# Altium schematic sheet CW551_MainBoard_USB.SchDoc
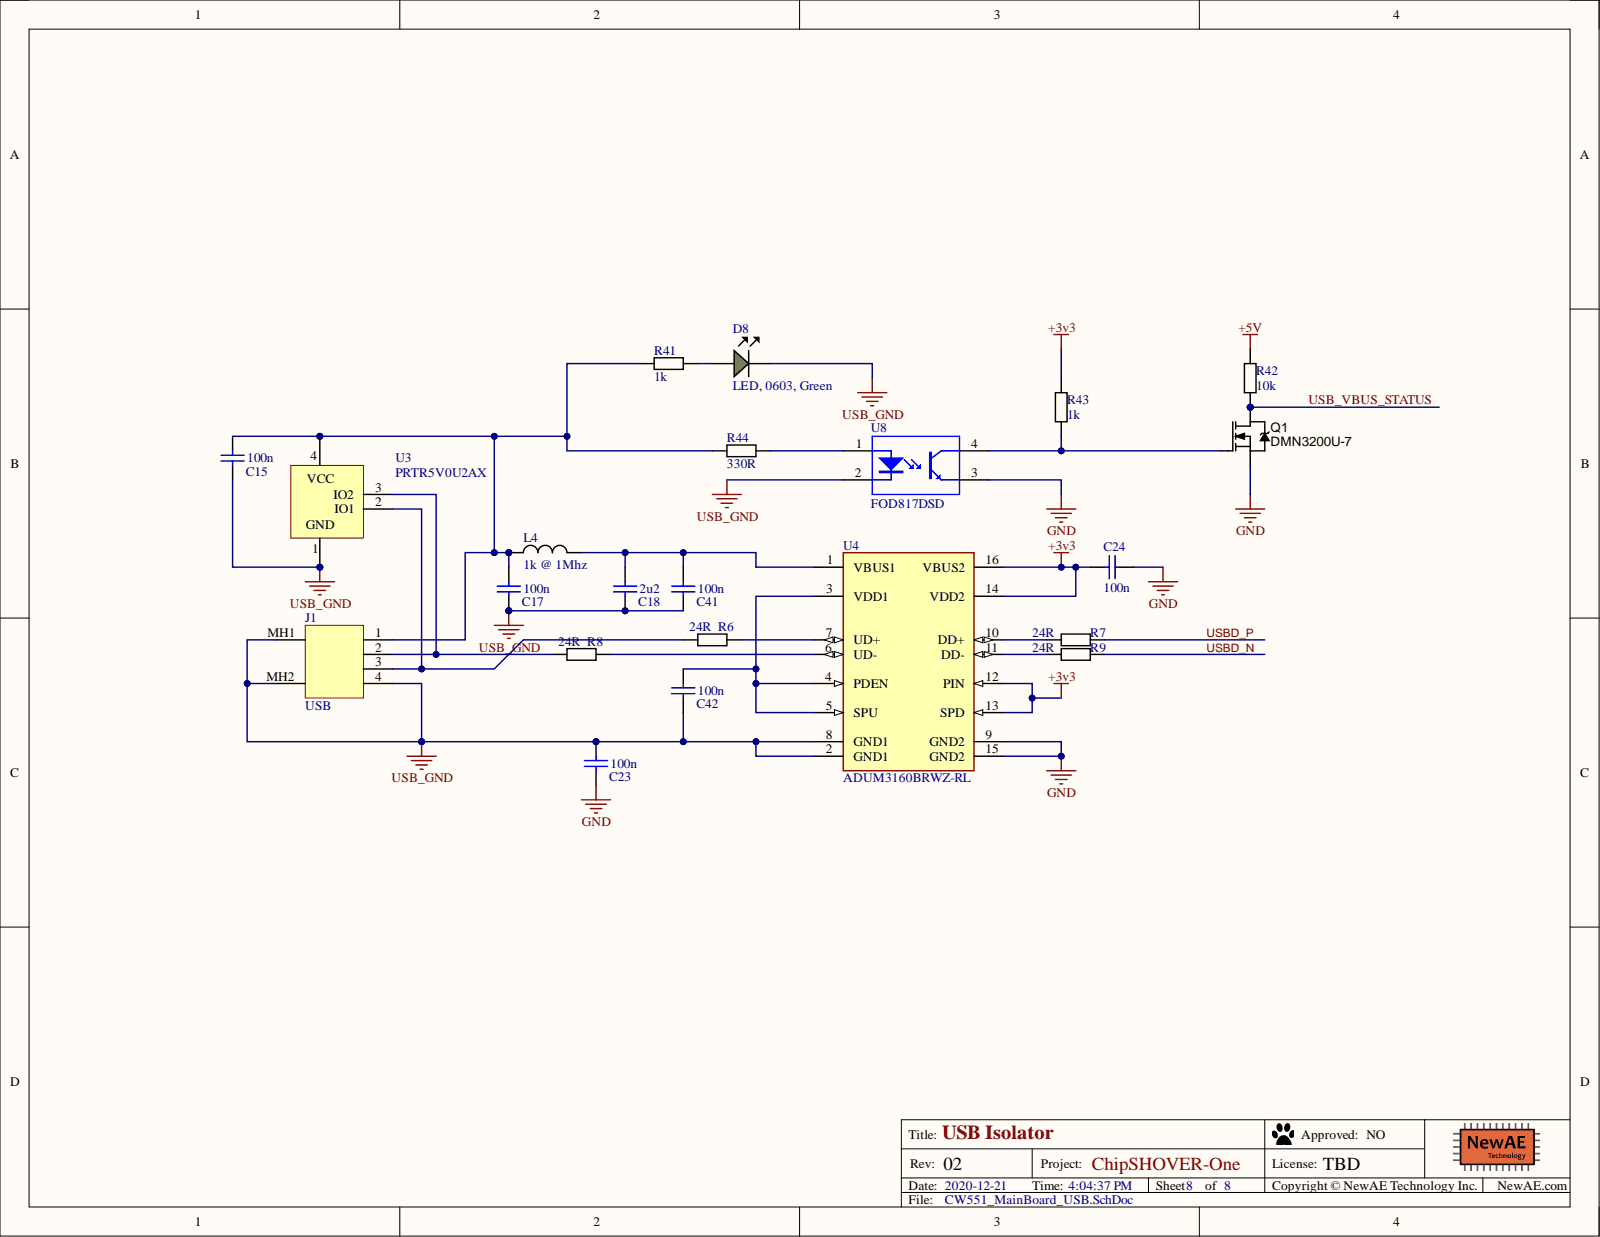
[diagram p0: CW551_MainBoard_USB.SchDoc]

## Parts
C15  100n  [0603]
C17  100n  [0603]
C18  2u2  [0603]
C23  100n  [0603]
C24  100n  [0603]
C41  100n  [0603]
C42  100n  [0603]
D8  LED, 0603, Green  [LED-0603-GREEN]
J1  USB  [USB_61729-0010BLF-6_V]
L4  1k @ 1Mhz  [0603]
Q1  DMN3200U-7  [SOT23]
R6  24R  [0603]
R7  24R  [0603]
R8  24R  [0603]
R9  24R  [0603]
R41  1k  [0603]
R42  10k  [0603]
R43  1k  [0603]
R44  330R  [0603]
U3  PRTR5V0U2AX  [SOT143B]
U4  ADUM3160BRWZ-RL  [FP-RW-16-IPC_C]
U8  FOD817DSD  [FAIR-MDIP-4_V]

## Nets
+3v3: C24.1 R43.1 U4.12(PIN) U4.13(SPD) U4.14(VDD2) U4.16(VBUS2)
+5V: R42.1
GND: C23.2 C24.2 Q1.2 U4.9(GND2) U4.15(GND2) U8.3
USB_GND: C15.2 C17.2 C18.2 C23.1 C41.2 C42.2 D8.K J1.4 J1.MH1 J1.MH2 U3.1 U4.2(GND1) U4.8(GND1) U8.2
USB_VBUS_STATUS: Q1.3 R42.2
USBD_N: R9.2
USBD_P: R7.2
NetC15_1: C15.1 C17.1 J1.1 L4.1 R41.1 R44.1 U3.4
NetC18_1: C18.1 C41.1 L4.2 U4.1(VBUS1)
NetC42_1: C42.1 U4.3(VDD1) U4.4(PDEN) U4.5(SPU)
NetD8_A: D8.A R41.2
NetJ1_2: J1.2 R8.1 U3.3(IO2)
NetJ1_3: J1.3 R6.1 U3.2(IO1)
NetQ1_1: Q1.1 R43.2 U8.4
NetR6_2: R6.2 U4.7(UD+)
NetR7_1: R7.1 U4.10(DD+)
NetR8_2: R8.2 U4.6(UD-)
NetR9_1: R9.1 U4.11(DD-)
NetR44_2: R44.2 U8.1

## Library data of the parts
C15 C17 C23 C24 C41 C42 = CCHIP-100n-0603: 100n, 0603; Manufacture 1: Kemet; Manufacture Part Number 1: C0603C104K5RACTU; Case-Metric: 1608; Component Group: Capacitor; Database Table Name: main; Rated Voltage: 50VDC; Case-EIA: 0603; Component Kind: Standard; Component Type: Standard; LCSC Equivalent: C1591; Library Name: NewAE_AltiumLib.DBLib; Mounting Technology: SMT; Part Number: CCHIP-100n-0603; Pin Count: 2; Supplier 1: Digi-Key; Supplier Part Number 1: 399-5089-2-ND; Value: 100n
C18 = CCHIP-2u2-0603: 2u2, 0603; Manufacture 1: Samsung; Manufacture Part Number 1: CL10B225KP8NNNC; Case-Metric: 1608; Component Group: Capacitor; Database Table Name: main; Rated Voltage: 10VDC; Case-EIA: 0603; Component Kind: Standard; Component Type: Standard; LCSC Equivalent: C57895; Library Name: NewAE_AltiumLib.DBLib; Mounting Technology: SMT; Part Number: CCHIP-2u2-0603; Pin Count: 2; Supplier 1: Digi-Key; Supplier Part Number 1: 1276-1134-2-ND; Value: 2u2
D8 = LEDCHIP_0603_GREEN: Chip LED, Green; Manufacture 1: Kingbright; Manufacture Part Number 1: APT1608CGCK; Component Group: Optical; Database Table Name: main; PP Material Stack: 16; PP Rotation: -90; Component Kind: Standard; Component Type: Standard; LCSC Equivalent: C192666; Library Name: NewAE_AltiumLib.DBLib; Mounting Technology: SMT; Part Number: LEDCHIP_0603_GREEN; Pin Count: 2; Supplier 1: Digi-Key; Supplier Part Number 1: 754-1116-1-ND
J1 = CMP-0397-00002-2: Single USB 2.0, B Type, Right Angle Jack, Through Hole, RoHS, Tube; Manufacturer: FCI Electronics; PartNumber: 61729-0010BLF; 0_TEMP: FCI-61729-0010BLF-6_V; Connector Type: USB-B; Gender: Receptacle; Number of Contacts: 4; Specifications: USB 2.0; Component Kind: Standard; ComponentLink1Description: Manufacturer URL; ComponentLink1URL: http://portal.fciconnect.com/; ComponentLink2Description: Datasheet; ComponentLink2URL: http://portal.fciconnect.com/Comergent//fci/drawing/61729.pdf; ComponentLink3Description: Package Specification; ComponentLink3URL: http://portal.fciconnect.com/Comergent//fci/drawing/61729.pdf; DatasheetVersion: Rev. V; Functionality: USB; Library Name: CW550_MAIN.SCHLIB; Mounting Technology: Through Hole; PackageDescription: 6-Pin, Through Hole, Body 12.2 x 16 mm; PackageReference: 61729-0010BLF; Packing: Tube; RoHS: TRUE; Supplier 1: Digi-Key; Supplier Part Number 1: 609-1039-ND
L4 = FBCHIP-1k-0603: Ferrite Beads HI CUR CHIP BD 0603 1000OHMS 25%; Manufacture 1: Taiyo Yuden; Manufacture Part Number 1: FBMH1608HM102-T FBMH1608HM102-T FBMH1608HM102-T FBMH1608HM102-T; Component Group: Inductor; Database Table Name: main; PP Material Stack: 0; Component Kind: Standard; Component Type: Standard; Library Name: NewAE_AltiumLib.DBLib; Mounting Technology: SMT; Part Number: FBCHIP-1k-0603; Pin Count: 2; PP Rotation: 0; RoHS: Yes; Supplier 1: Mouser; Supplier Part Number 1: 963-FBMH1608HM102-T
Q1 = DMN3200U-7: MOSFET N-CH 30V 2.2A SOT23-3; Manufacture 1: Diodes Inc.; Database Table Name: main; PP Material Stack: 0; Rated Power: 3A; Component Group: MOSFET; Component Kind: Standard; Component Type: Standard; LCSC Equivalent: C461018; Library Name: NewAE_AltiumLib.DBLib; Manufacture Part Number 1: DMN3200U-7; Mounting Technology: SMT; Part Number: DMN3200U-7; Pin Count: 3; PP Rotation: 0; Rated Voltage: 30V; RoHS: Yes; Supplier 1: Digi-Key; Supplier Part Number 1: DMN3200U-7DICT-ND
R6-R9 = RCHIP-24R-0603: 24R res, 0603, 1%, 1/10W; Manufacture 1: Yageo; Manufacture Part Number 1: RC0603FR-0724RL; Case-Metric: 1608; Component Group: Resistor; Database Table Name: main; Rated Power: 0.1W; Rated Voltage: 75VDC; Case-EIA: 0603; Component Kind: Standard; Component Type: Standard; Library Name: NewAE_AltiumLib.DBLib; Mounting Technology: SMT; Part Number: RCHIP-24R-0603; Pin Count: 2; Supplier 1: Digi-Key; Supplier Part Number 1: 311-24.0HRCT-ND; Temperature Range: -55C to 155C; Tolerance: 1%; Value: 24R
R41 R43 = RCHIP-1K-0603: 1k res, 0603, 1%, 1/10W; Manufacture 1: Yageo; Manufacture Part Number 1: RC0603FR-071KL; Case-Metric: 1608; Component Group: Resistor; Database Table Name: main; Rated Power: 0.1W; Rated Voltage: 75VDC; Case-EIA: 0603; Component Kind: Standard; Component Type: Standard; Library Name: NewAE_AltiumLib.DBLib; Mounting Technology: SMT; Part Number: RCHIP-1K-0603; Pin Count: 2; Supplier 1: Digi-Key; Supplier Part Number 1: 311-1.00KHRCT-ND; Temperature Range: -55C to 155C; Tolerance: 1%; Value: 1k
R42 = RCHIP-10K-0603: 10k res, 0603, 1%, 1/10W; Manufacture 1: Yageo; Manufacture Part Number 1: RC0603FR-0710KL; Case-Metric: 1608; Component Group: Resistor; Database Table Name: main; Rated Power: 0.1W; Rated Voltage: 75VDC; Case-EIA: 0603; Component Kind: Standard; Component Type: Standard; Library Name: NewAE_AltiumLib.DBLib; Mounting Technology: SMT; Part Number: RCHIP-10K-0603; Pin Count: 2; Supplier 1: Digi-Key; Supplier Part Number 1: 311-10.0KHRCT-ND; Temperature Range: -55C to 155C; Tolerance: 1%; Value: 10k
R44 = RCHIP-330R-0603: 330R res, 0603, 1%, 1/10W; Manufacture 1: Yageo; Manufacture Part Number 1: RC0603FR-07330RL; Case-Metric: 1608; Component Group: Resistor; Database Table Name: main; Rated Power: 0.1W; Rated Voltage: 75VDC; Case-EIA: 0603; Component Kind: Standard; Component Type: Standard; Library Name: NewAE_AltiumLib.DBLib; Mounting Technology: SMT; Part Number: RCHIP-330R-0603; Pin Count: 2; Supplier 1: Digi-Key; Supplier Part Number 1: 311-330HRCT-ND; Temperature Range: -55C to 155C; Tolerance: 1%; Value: 330R
U3 = PRTR5V0U2AX: USB 2.0 high-speed ESD; Component Group: Diode; 0_TEMP: SOT143B; Component Kind: Standard; Component Type: Standard; LCSC Equivalent: C455074; Library Name: CW550_MAIN.SCHLIB; Part Number: PRTR5V0U2AX; Pin Count: 4; Supplier 1: Digi-Key; Supplier Part Number 1: 568-7445-1-ND
U4 = CMP-09093-000002-1: DGTL ISO 2.5KV 2CH USB 16SOIC; Manufacturer: Analog Devices; Automotive Grade: Grade 2; Max Supply Voltage: 5.5V; Min Supply Voltage: 3V; Nominal Supply Current: 5-6mA; Standoff Height: 0.1mm; Ambient Temperature Range High: +105°C; Ambient Temperature Range Low: -40°C; Automotive: Yes; Category: IC; Component Kind: Standard; ComponentLink2Description: Datasheet; ComponentLink2URL: http://datasheet.octopart.com/ADUM3160BRWZ-RL-Analog-Devices-datasheet-10195649.pdf; Device Class L1: Integrated Circuits (ICs); Device Class L2: Interface ICs; Device Class L3: unset; Digikey Description: DGTL ISO 2.5KV 2CH USB 16SOIC; Height: 2.65mm; Interface: USB; Ipc Land Pattern Name: SOIC127P1032X250-16; LCSC Part: C284149; Lead Free: Yes; Library Name: Altium Content Vault; Manufacturer Part Number: ADUM3160BRWZ-RL; Mouser Description: Digital Isolators Full/Low Spd USB 2.0 Digital; Octopart: https://octopart.com/adum3160brwz-rl-analog+devices-18672074; Package: RW-16; RoHS: TRUE; Temperature: -40°C to +105°C; Temperature Range High: +105°C; Temperature Range Low: -40°C
U8 = CMP-2000-06281-1: Phototransistor Optocoupler, 4 us Rise, 3 us Fall, 5000 Vrms, -55 to 110 degC, 4-Pin MDIP, RoHS, Tape and Reel; Manufacturer: Fairchild Semiconductor; Current - DC Forward (If) (Max): 50mA; Current Transfer Ratio (Max): 600%@5mA; Current Transfer Ratio (Min): 300%@5mA; Input Type: DC; Number of Channels: 1; Online Catalog: FOD617,814,817Series; Other Names: FOD817DSDDKR; Package Version: 10/2014; Rise / Fall Time (Typ): 4µs,3µs; Vce Saturation (Max): 200mV; Voltage - Forward (Vf) (Typ): 1.2V; Voltage - Output (Max): 70V; Category: Isolators; Component Kind: Standard; Current - Output / Channel: 50mA; Datasheet URL: https://www.fairchildsemi.com/datasheets/FO/FOD817D.pdf; Datasheet Version: Rev. 2.8, 11/2015; Family: Optoisolators-Transistor,PhotovoltaicOutput; LCSC Part: C241832; Library Name: Altium Content Vault; Manufacturer URL: https://www.fairchildsemi.com/; Mounting Technology: SurfaceMount; Mounting Type: SurfaceMount; Operating Temperature: -55°C~110°C; Output Type: Transistor; Package / Case: 4-SMD,GullWing; Package Description: 4-Pin Surface Mount Device, Body 6.5 x 4.6 mm, Pitch 2.54 mm; Package Reference: MDIP 4L; Package URL: https://www.fairchildsemi.com/package-drawings/N0/N04C.pdf; Packaging: Digi-Reel®; Series: -; Standard Package: 1; Supplier Device Package: 4-SMD; Turn On / Turn Off Time (Typ): -; Voltage - Isolation: 5000Vrms
